# Altium schematic sheet power.SchDoc
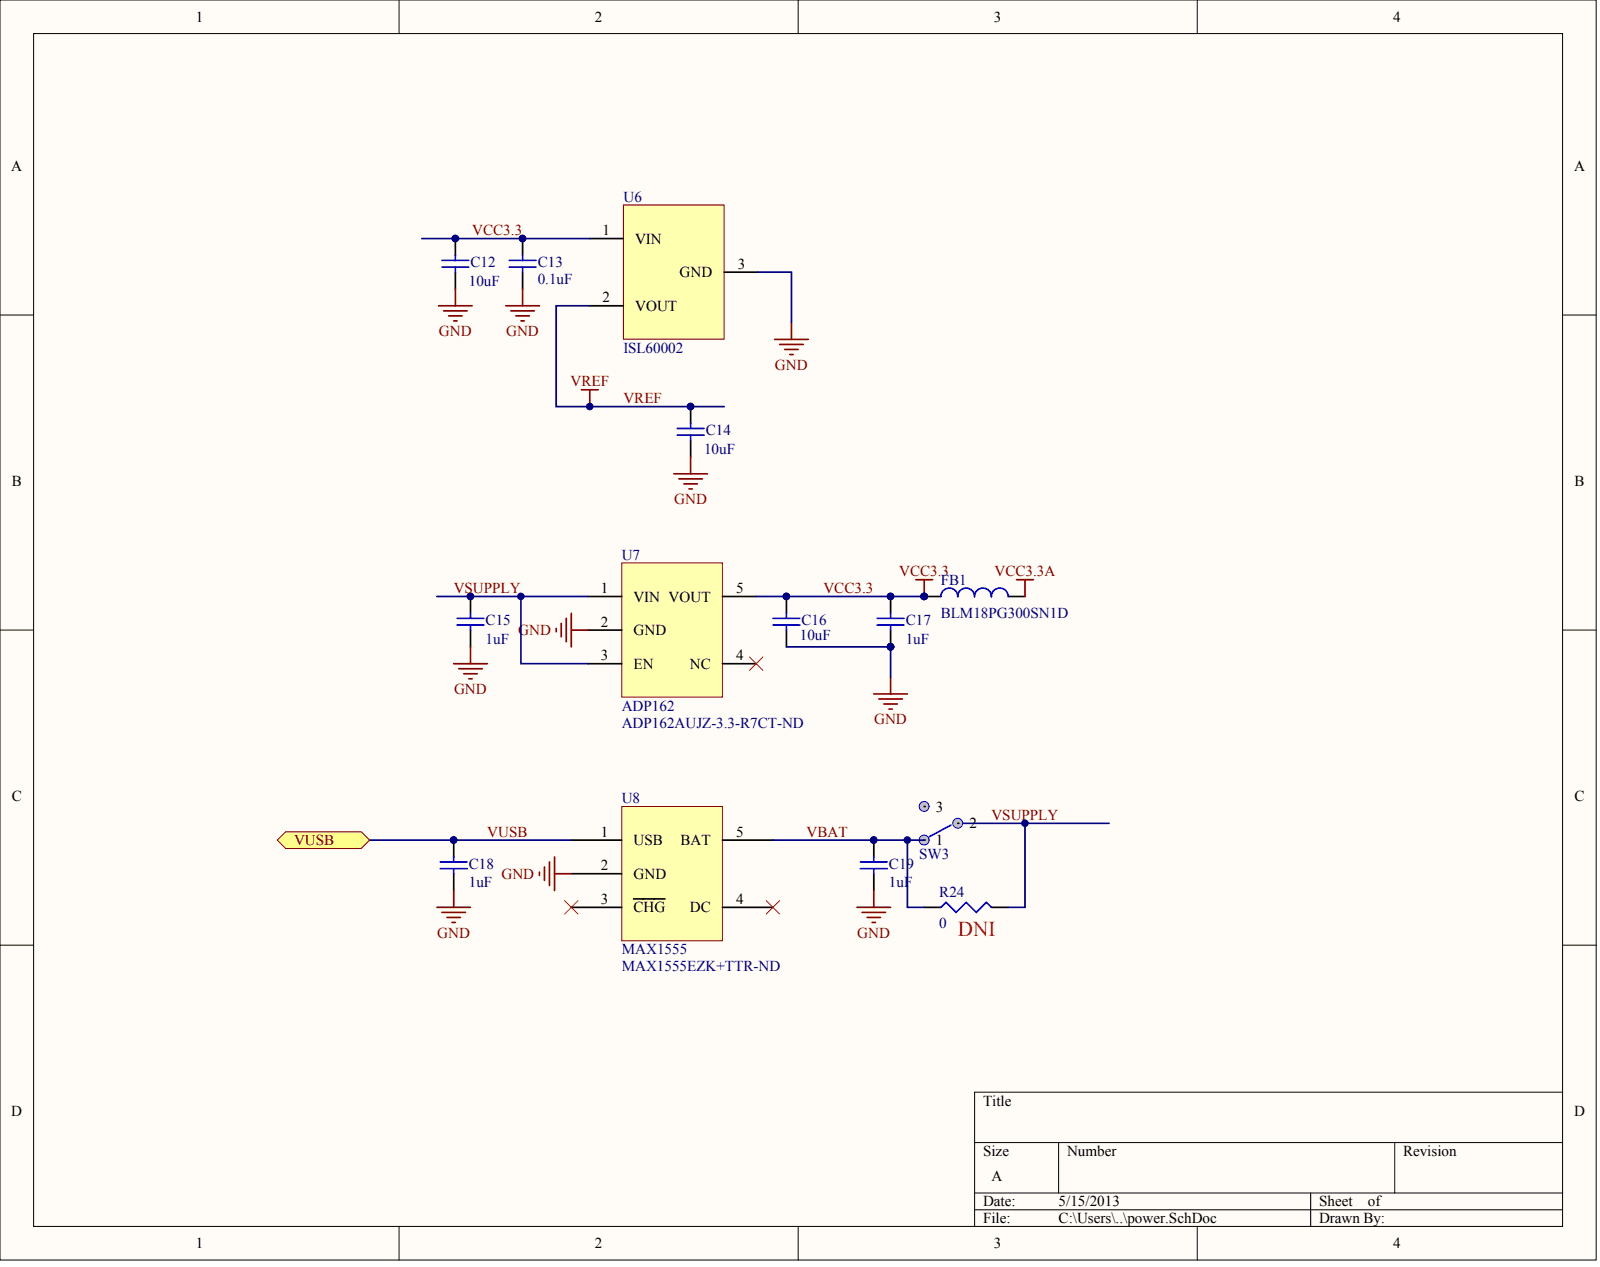
[diagram p0: power.SchDoc]

## Parts
C12  value 10uF  (hidden comment: Cap Semi)
C13  value 0.1uF  (hidden comment: Cap Semi)
C14  value 10uF  (hidden comment: Cap Semi)
C15  value 1uF  (hidden comment: Cap Semi)
C16  value 10uF  (hidden comment: Cap Semi)
C17  value 1uF  (hidden comment: Cap Semi)
C18  value 1uF  (hidden comment: Cap Semi)
C19  value 1uF  (hidden comment: Cap Semi)
FB1  BLM18PG300SN1D
R24  value 0  (hidden comment: Res3)
SW3  EG1218  (value not drawn)
U6  ISL60002
U7  ADP162  Supplier Part Number 1: ADP162AUJZ-3.3-R7CT-ND
U8  MAX1555  Supplier Part Number 1: MAX1555EZK+TTR-ND

## Ports
VUSB

## Nets
GND: C12.1 C13.1 C14.1 C15.1 C16.1 C17.1 C18.1 C19.1 U6.3 U7.2(GND) U8.2(GND)
VCC3.3A: FB1.2
VBAT: C19.2 R24.1 SW3.1 U8.5(BAT)
VCC3.3: C12.2 C13.2 C16.2 C17.2 FB1.1 U6.1 U7.5(VOUT)
VREF: C14.2 U6.2
VSUPPLY: C15.2 R24.2 SW3.2 U7.1(VIN) U7.3(EN)
VUSB: C18.2 U8.1(USB) ; port VUSB

Not connected: SW3 3; U7 4(NC); U8 3(CHG) 4(DC)

## Library data of the parts
C12-C19 FB1 R24 SW3 U6-U8 = (no symbol name)
  C12-C19: Published: 8-Jun-2000; LatestRevisionDate: 29-May-2009; LatestRevisionNote: IPC-7351 Footprint Added.; PackageReference: C1206; PackageDescription: Chip Capacitor
  FB1: Supplier 1: Digi-Key; Supplier Part Number 1: 490-1035-2-ND
  R24: Published: 8-Jun-2000; LatestRevisionDate: 29-May-2009; LatestRevisionNote: IPC-7351 Footprint Added.; PackageReference: J1-0603; PackageDescription: Chip Resistor
  SW3: Supplier 1: Digi-Key; Supplier Part Number 1: EG1903-ND; Supplier 2: Digi-Key; Supplier Part Number 2: 450-1598-ND; Supplier 3: Digi-Key; Supplier Part Number 3: 450-1577-ND
  U6: Supplier 1: Digi-Key; Supplier Part Number 1: ISL60002DIH310Z-TKCT-ND
  U7 U8: Supplier 1: Digi-Key
  C12-C19 R24: Publisher: Altium Limited
